# Altium schematic sheet M10S Breakout Schematic.SchDoc("M10S Breakout Schematic")
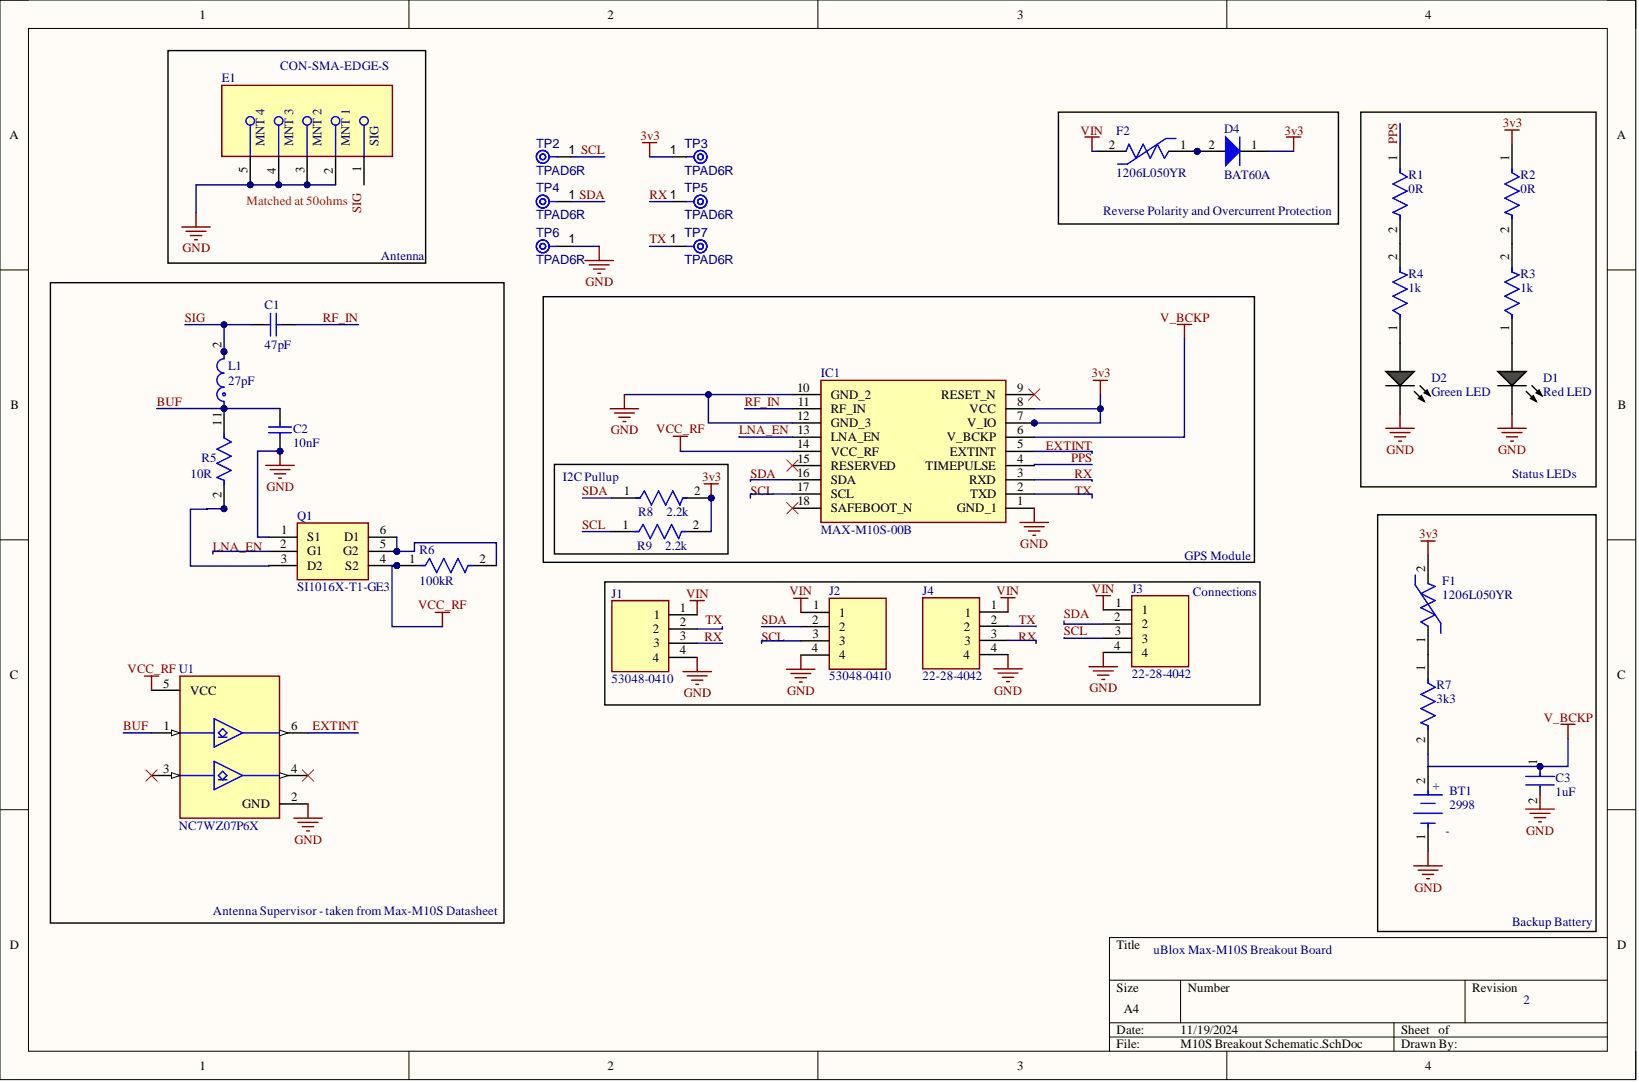
[diagram p0: M10S Breakout Schematic.SchDoc]

## Parts
BT1  2998
C1  47pF
C2  10nF
C3  1uF
D1  Red LED
D2  Green LED
D4  BAT60A
E1  CON-SMA-EDGE-S
F1  1206L050YR
F2  1206L050YR
IC1  MAX-M10S-00B
J1  53048-0410
J2  53048-0410
J3  22-28-4042
J4  22-28-4042
L1  27pF
Q1  SI1016X-T1-GE3
R1  0R
R2  0R
R3  1k
R4  1k
R5  10R
R6  100kR
R7  3k3
R8  2.2k
R9  2.2k
TP2  TPAD6R  (no BOM)
TP3  TPAD6R  (no BOM)
TP4  TPAD6R  (no BOM)
TP5  TPAD6R  (no BOM)
TP6  TPAD6R  (no BOM)
TP7  TPAD6R  (no BOM)
U1  NC7WZ07P6X

## Nets
3v3: D4.1 F1.2 IC1.7(V_IO) IC1.8(VCC) R2.1 R8.2 R9.2 TP3.1
GND: BT1.1 C2.2 C3.2 D1.2 D2.2 E1.2 E1.3 E1.4 E1.5 IC1.1(GND_1) IC1.10(GND_2) IC1.12(GND_3) J1.4 J2.4 J3.4 J4.4 Q1.1(S1) TP6.1 U1.2(GND)
V_BCKP: BT1.2 C3.1 IC1.6(V_BCKP) R7.2
VCC_RF: IC1.14(VCC_RF) Q1.4(S2) R6.1 U1.5(VCC)
VIN: F2.2 J1.1 J2.1 J3.1 J4.1
BUF: C2.1 L1.1 R5.1 U1.1
EXTINT: IC1.5(EXTINT) U1.6
LNA_EN: IC1.13(LNA_EN) Q1.2(G1)
PPS: IC1.4(TIMEPULSE) R1.1
RF_IN: C1.2 IC1.11(RF_IN)
RX: IC1.3(RXD) J1.3 J4.3 TP5.1
SCL: IC1.17(SCL) J2.3 J3.3 R9.1 TP2.1
SDA: IC1.16(SDA) J2.2 J3.2 R8.1 TP4.1
SIG: C1.1 L1.2
TX: IC1.2(TXD) J1.2 J4.2 TP7.1
NetD1_1: D1.1 R3.1
NetD2_1: D2.1 R4.1
NetD4_2: D4.2 F2.1
NetF1_1: F1.1 R7.1
NetQ1_3: Q1.3(D2) R5.2
NetQ1_5: Q1.5(G2) Q1.6(D1) R6.2
NetR1_2: R1.2 R4.2
NetR2_2: R2.2 R3.2

Not connected: E1 1; IC1 9(RESET_N) 15(RESERVED) 18(SAFEBOOT_N); U1 3 4

## Library data of the parts
BT1 = CMP-19636-000010-1: BATTERY RETAINER COIN 6.8MM SMD; Number of Batteries: 1; Case/Package: SMD/SMT; Color: Silver; Component Kind: Standard; Contact Material: Bronze; Height: 2.9mm; Lead Free: Lead Free; Library Name: Altium Content Vault; Material: Bronze; Mount: Surface Mount; Number of Cells: 1; Package Quantity: 100; REACH SVHC: No SVHC; Termination: SMD/SMT
C1 = CMP-2006-02325-1: Manufacturer: Murata; Part Number: GCM1885C1H470JA16D; Component Kind: Standard; Library Name: Altium Content Vault
C2 = CMP-2006-02373-1: Manufacturer: Murata; Part Number: GCM188R71H103KA37D; Component Kind: Standard; Library Name: Altium Content Vault
C3 = CMP-2006-02358-2: Ceramic Capacitor for Automotive 1uF  ±10% 16VDC X7R 0603 Paper T/R; Voltage Rating: 16V; Capacitance: 1uF; Case Code (Imperial): 0603; Case Code (Metric): 1608; Case/Package: 0603; Component Kind: Standard; Depth: 0.8mm; Dielectric: X7R; Lead Free: Lead Free; Length: 1.6mm; Library Name: Altium Content Vault; Max Operating Temperature: 125°C; Min Operating Temperature: -55°C; Mount: Surface Mount; Packaging: Tape and Reel; Radiation Hardening: No; Ratings: AEC-Q200; Thickness: 0.035inch; Tolerance: 10%; Voltage Rating (DC): 16V; Width: 0.031inch
D1 D2 = CMP-1744-00002-1: Chip LED, Green, 2.2 V, -40 to 85 degC, 2-Pin SMD, RoHS, Tape and Reel; Access Time: 3500 ns; Case\Package: SOIC; Clock Frequency: 100 KHz; Density: 32 kb; Frequency: 400 KHz; Interface: Serial; Max Supply Voltage: 5.5 V; Min Supply Voltage: 1.7 V; Nominal Supply Current: 2 mA; Component Kind: Standard; ComponentLink1Description: Manufacturer URL; ComponentLink1URL: http://www.avagotech.com/; ComponentLink2Description: Datasheet; ComponentLink2URL: http://docs.avagotech.com/docs/AV02-0551EN; ComponentLink3Description: Package Specification; ComponentLink3URL: http://www.fairchildsemi.com/dwg/MA/MA03D.pdf; DatasheetVersion: Mar-12; Height: 1.5 mm; Length: 5 mm; Library Name: Altium Content Vault; Max Clock Frequency: 100 KHz; Max Operating Temperature: 85 degC; Min Operating Temperature: -40 degC; Mount: Surface Mount; Package Quantity: 3000; PackageDescription: 2-Pin Surface Mount Device, Body 1.6 x 0.8 mm, Height 0.9 mm; PackageReference: HSMX-C190; Packaging: Tape and Reel; Pins: 8; Radiation Hardening: No; REACH SVHC: No SVHC; RoHSCompliant: true; Width: 4 mm
D4 = CMP-1183-00008-2: Silicon Schottky Diode, -55 to 85 degC, SOD323, Reel, Green; Manufacturer: Infineon; Configuration: Single; CT (max): 35Â pF; Green: TRUE; IF (max): 3,000Â mA; VF (max): 370Â mV; VR (max): 10Â V; Component Kind: Standard; ComponentLink1Description: Manufacturer URL; ComponentLink1URL: http://www.infineon.com/; ComponentLink2Description: Datasheet; ComponentLink2URL: http://www.infineon.com/dgdl/bat60aseries.pdf?folderId=db3a304313d846880113def5812204a1&fileId=db3a304313d846880113def70c9304a9; ComponentLink3Description: Package Specification; ComponentLink3URL: http://www.infineon.com/dgdl/SOT323-Package_Overview.pdf?folderId=db3a30431936bc4b0119533e1dcb3c92&fileId=db3a30431936bc4b011953495f283cb1; Library Name: Altium Content Vault; Mounting Technology: Surface Mount; PackageDescription: 3-Pin Small Outline Transistor Package, 1.25 x 2 mm Body, 0.65 mm Pitch; PackageReference: SOT323; Packing: Reel; PartNumber: BAT60A
E1 = CMP-002-00071-2: RF SMA Connector, 1 Contact(s), Female, Board Mount, Surface Mount Terminal, Locking; Component Kind: Standard; Library Name: Sean McDougall
F1 F2 = CMP-08606-000073-1: PTC RESET FUSE 6V 500MA 1206; Approvals: cULus; Current Rating: 100A; Max Power Dissipation: 600mW; Resistance: 700mR; Time to Trip: 0.1s; Trip Current: 1A; Case Code (Imperial): 1206; Case Code (Metric): 3216; Case/Package: 1206; Component Kind: Standard; Height: 0.75mm; Hold Current: 500mA; Lead Free: Lead Free; Length: 3.4mm; Library Name: Altium Content Vault; Max Current Rating: 100A; Max Operating Temperature: 85°C; Max Voltage Rating (DC): 6V; Min Operating Temperature: -40°C; Operating Supply Voltage: 6V; Package Quantity: 4000; Packaging: Tape and Reel; Power Dissipation: 600mW; REACH SVHC: No SVHC; RoHS Compliant: Yes; Series: 1206L; Terminal Material: Copper; Termination: SMD/SMT; Voltage Rating: 6V; Voltage Rating (DC): 6V; Width: 1.8mm; Working Voltage: 6V
IC1 = MAX-M10S-00B: Integrated Circuit; Manufacturer_Name: U-Blox; Component Kind: Standard; Datasheet Link: https://content.u-blox.com/sites/default/files/MAX-M10S_IntegrationManual_UBX-20053088.pdf; Height: 2.7mm; Manufacturer_Part_Number: MAX-M10S-00B; Mouser Part Number: 377-MAX-M10S-00B; Mouser Price/Stock: https://www.mouser.co.uk/ProductDetail/u-blox/MAX-M10S-00B?qs=A6eO%252BMLsxmT0PfQYPb7LLQ%3D%3D
J1 J2 = 53048-0410: Connector; Manufacturer_Name: Molex; Component Kind: Standard; Datasheet Link: https://www.molex.com/pdm_docs/sd/530480410_sd.pdf; Height: 3.7mm; Manufacturer_Part_Number: 53048-0410; Mouser Part Number: 538-53048-0410; Mouser Price/Stock: https://www.mouser.co.uk/ProductDetail/Molex/53048-0410?qs=359VwiUTsp2msj5Tobchuw%3D%3D
J3 J4 = 22-28-4042: Connector; Manufacturer_Name: Molex; Component Kind: Standard; Datasheet Link: https://www.molex.com/content/dam/molex/molex-dot-com/products/automated/en-us/salesdrawingpdf/423/42375/022284042_sd.pdf?inline; Height: 10.03mm; Manufacturer_Part_Number: 22-28-4042; Mouser Part Number: 538-22-28-4042; Mouser Price/Stock: https://www.mouser.co.uk/ProductDetail/Molex/22-28-4042?qs=GAPJcf4kvdu6DqYOOlzdaQ%3D%3D
L1 = CMP-06042-012021-1: Multilayer type Inductor for Automotive 27nH ±5% 0.54Ω 500mA 0603; DC Resistance (DCR): 4.18mR; Case/Package: 0603; Component Kind: Standard; Inductance: 27nH; Library Name: Altium Content Vault; Package Quantity: 4000; Packaging: Tape and Reel; Termination: SMD/SMT
Q1 = SI1016X-T1-GE3: Transistor; Manufacturer_Name: Vishay; Arrow Part Number: SI1016X-T1-GE3; Arrow Price/Stock: https://www.arrow.com/en/products/si1016x-t1-ge3/vishay?region=nac; Component Kind: Standard; Datasheet Link: https://componentsearchengine.com/Datasheets/1/SI1016X-T1-GE3.pdf; Height: 0.6mm; Manufacturer_Part_Number: SI1016X-T1-GE3; Mouser Part Number: 781-SI1016X-T1-GE3; Mouser Price/Stock: https://www.mouser.co.uk/ProductDetail/Vishay-Semiconductors/SI1016X-T1-GE3?qs=tWM4QuTcS4UASHtTakd5XQ%3D%3D
R1 R2 = CMP-03412-047235-1: RES 0Ω 0.00% 0.1W 0603; Resistance: 0mR; Tolerance: 5%; Case/Package: 0603; Component Kind: Standard; Lead Free: Lead Free; Library Name: Altium Content Vault; RoHS Compliant: Yes
R3 R4 = CMP-2000-04863-2: RES 1kΩ 5.00% 0.1W 0603; Max Power Dissipation: 100mW; Resistance: 1kR; Temperature Coefficient: 100ppm/°C; Tolerance: 5%; Voltage Rating: 50V; Case Code (Imperial): 0603; Case Code (Metric): 1608; Case/Package: 0603; Component Kind: Standard; Construction: Rectangular; Contact Plating: Tin; Depth: 0.8mm; Features: Moisture Resistant; Height: 0.45mm; Lead Free: Lead Free; Length: 1.6mm; Library Name: Altium Content Vault; Max Operating Temperature: 155°C; Military Standard: Not; Min Operating Temperature: -55°C; Number of Terminations: 2; Packaging: Tape and Reel; Power Rating: 100mW; Radiation Hardening: No; Ratings: AEC-Q200; Resistor Type: Thick Film; RoHS Compliant: Yes; Series: AC; Size Code: 0603; Termination: SMD/SMT; Width: 0.8mm
R5 = CMP-03412-007974-1: RES SMD 10 OHM 5% 1/4W 1206; Manufacturer: Yageo; Manufacturer Part Number: AC1206JR-0710RL; Automotive Grade: Grade 0; Power Rating: 0.25W; Resistance: 10Ω; Tolerance: 0.05; Value: 10Ω; Voltage Rating: 200V; Automotive: Yes; Category: Res; Component Kind: Standard; ComponentLink2Description: Datasheet; ComponentLink2URL: http://www.yageo.com/NewPortal/yageodocoutput?fileName=/pdf/R-Chip/PYu-AC_51_RoHS_L_6.pdf; Composition: Thick Film; Device Class L1: Passive Components; Device Class L2: Resistors; Device Class L3: Chip SMD Resistors; Digikey Description: RES SMD 10 OHM 5% 1/4W 1206; Footprint Url: http://www.yageo.com/exep/pages/download/literatures/PYu-R_Mount_10.pdf; Height: 0.65mm; Ipc Land Pattern Name: RESC310160X55; Lead Free: Yes; Library Name: Altium Content Vault; Mouser Description: Res Thick Film 1206 10 Ohm 5% 0.25W(1/4W) ±200ppm/C Epoxy Pad SMD Automotive T/R; Octopart: https://octopart.com/ac1206jr-0710rl-yageo-30511263; Package: AC1206; RoHS: TRUE; Series: AC; Temperature: -55°C to +155°C; Temperature Range High: +155°C; Temperature Range Low: -55°C
R6 = CMP-2000-04816-3: RES 100KΩ 1.00% 0.1W 0603; Max Power Dissipation: 100mW; Temperature Coefficient: 100ppm/°C; Tolerance: 1%; Voltage Rating: 50V; Working Voltage: 75V; Case Code (Imperial): 0603; Case Code (Metric): 1608; Case/Package: 0603; Component Kind: Standard; Construction: Rectangular; Depth: 0.8mm; Features: Moisture Resistant; Height: 0.022inch; Lead Free: Lead Free; Length: 1.6mm; Library Name: Altium Content Vault; Max Operating Temperature: 155°C; Military Standard: Not; Min Operating Temperature: -55°C; Moisture Sensitivity Level: 1; Number of Terminations: 2; Package Quantity: 1; Packaging: Tape and Reel; Power Rating: 100mW; Ratings: AEC-Q200; Resistance: 100kR; Resistor Type: Thick Film; RoHS Compliant: Yes; Series: AC; Technology: THICK FILM; Termination: SMD/SMT; Width: 0.031inch
R7 = CMP-03412-024727-2: RES 3.3KΩ 1.00% 0.1W 0603; Max Power Dissipation: 100mW; Resistance: 3.3kR; Temperature Coefficient: 100ppm/°C; Tolerance: 1%; Voltage Rating: 50V; Case Code (Imperial): 0603; Case Code (Metric): 1608; Case/Package: 0603; Component Kind: Standard; Construction: Rectangular; Depth: 0.8mm; Features: Moisture Resistant; Height: 0.45mm; Lead Free: Lead Free; Length: 1.6mm; Library Name: Altium Content Vault; Max Operating Temperature: 155°C; Military Standard: Not; Min Operating Temperature: -55°C; Number of Terminations: 2; Packaging: Tape and Reel; Power Rating: 100mW; Ratings: AEC-Q200; Resistor Type: Thick Film; RoHS Compliant: Yes; Series: AC; Size Code: 0603; Termination: SMD/SMT; Width: 0.8mm
R8 R9 = CMP-2000-04835-3: RES 2.2KΩ 1.00% 0.1W 0603; Max Power Dissipation: 100mW; Resistance: 2.2kR; Temperature Coefficient: 100ppm/°C; Tolerance: 1%; Voltage Rating: 50V; Case Code (Imperial): 0603; Case Code (Metric): 1608; Case/Package: 0603; Component Kind: Standard; Construction: Rectangular; Depth: 0.8mm; Features: Moisture Resistant; Height: 0.022inch; Lead Free: Lead Free; Length: 0.063inch; Library Name: Altium Content Vault; Max Operating Temperature: 155°C; Military Standard: Not; Min Operating Temperature: -55°C; Number of Terminations: 2; Package Quantity: 1; Packaging: Tape and Reel; Power Rating: 100mW; Ratings: AEC-Q200; Resistor Type: Thick Film; RoHS Compliant: Yes; Series: AC; Size Code: 0603; Termination: SMD/SMT; Width: 0.031inch
TP2-TP7 = CMP-00028-00002-2: 0.6mm round; Pins: 1; Library Name: Sean McDougall
U1 = CMP-2000-06822-2: IC BUF NON-INVERT 5.5V SC70-6; High Level Output Current: 32mA; Logic Function: Buffer; Max Power Dissipation: 180mW; Max Supply Current: 24mA; Min Supply Voltage: 1.65V; Number of Bits: 2; Number of Bits per Element: 1; Polarity: Non-Inverting; Propagation Delay: 12.6ns; Quiescent Current: 1uA; Turn-On Delay Time: 11.5ns; Case/Package: SC; Component Kind: Standard; Height: 1mm; Lead Free: Lead Free; Length: 2mm; Library Name: Altium Content Vault; Low Level Output Current: 32mA; Max Operating Temperature: 85°C; Max Supply Voltage: 5.5V; Min Operating Temperature: -40°C; Mount: Surface Mount; Number of Channels: 2; Number of Circuits: 2; Number of Elements: 2; Number of Input Lines: 2; Number of Inputs: 2; Number of Output Lines: 2; Number of Outputs: 2; Number of Pins: 6; Package Quantity: 1; Packaging: Tape and Reel; Radiation Hardening: No; REACH SVHC: No SVHC; Termination: SMD/SMT; Weight: 0.028g; Width: 1.25mm
